# Altium schematic sheet Payload_Switch.SchDoc
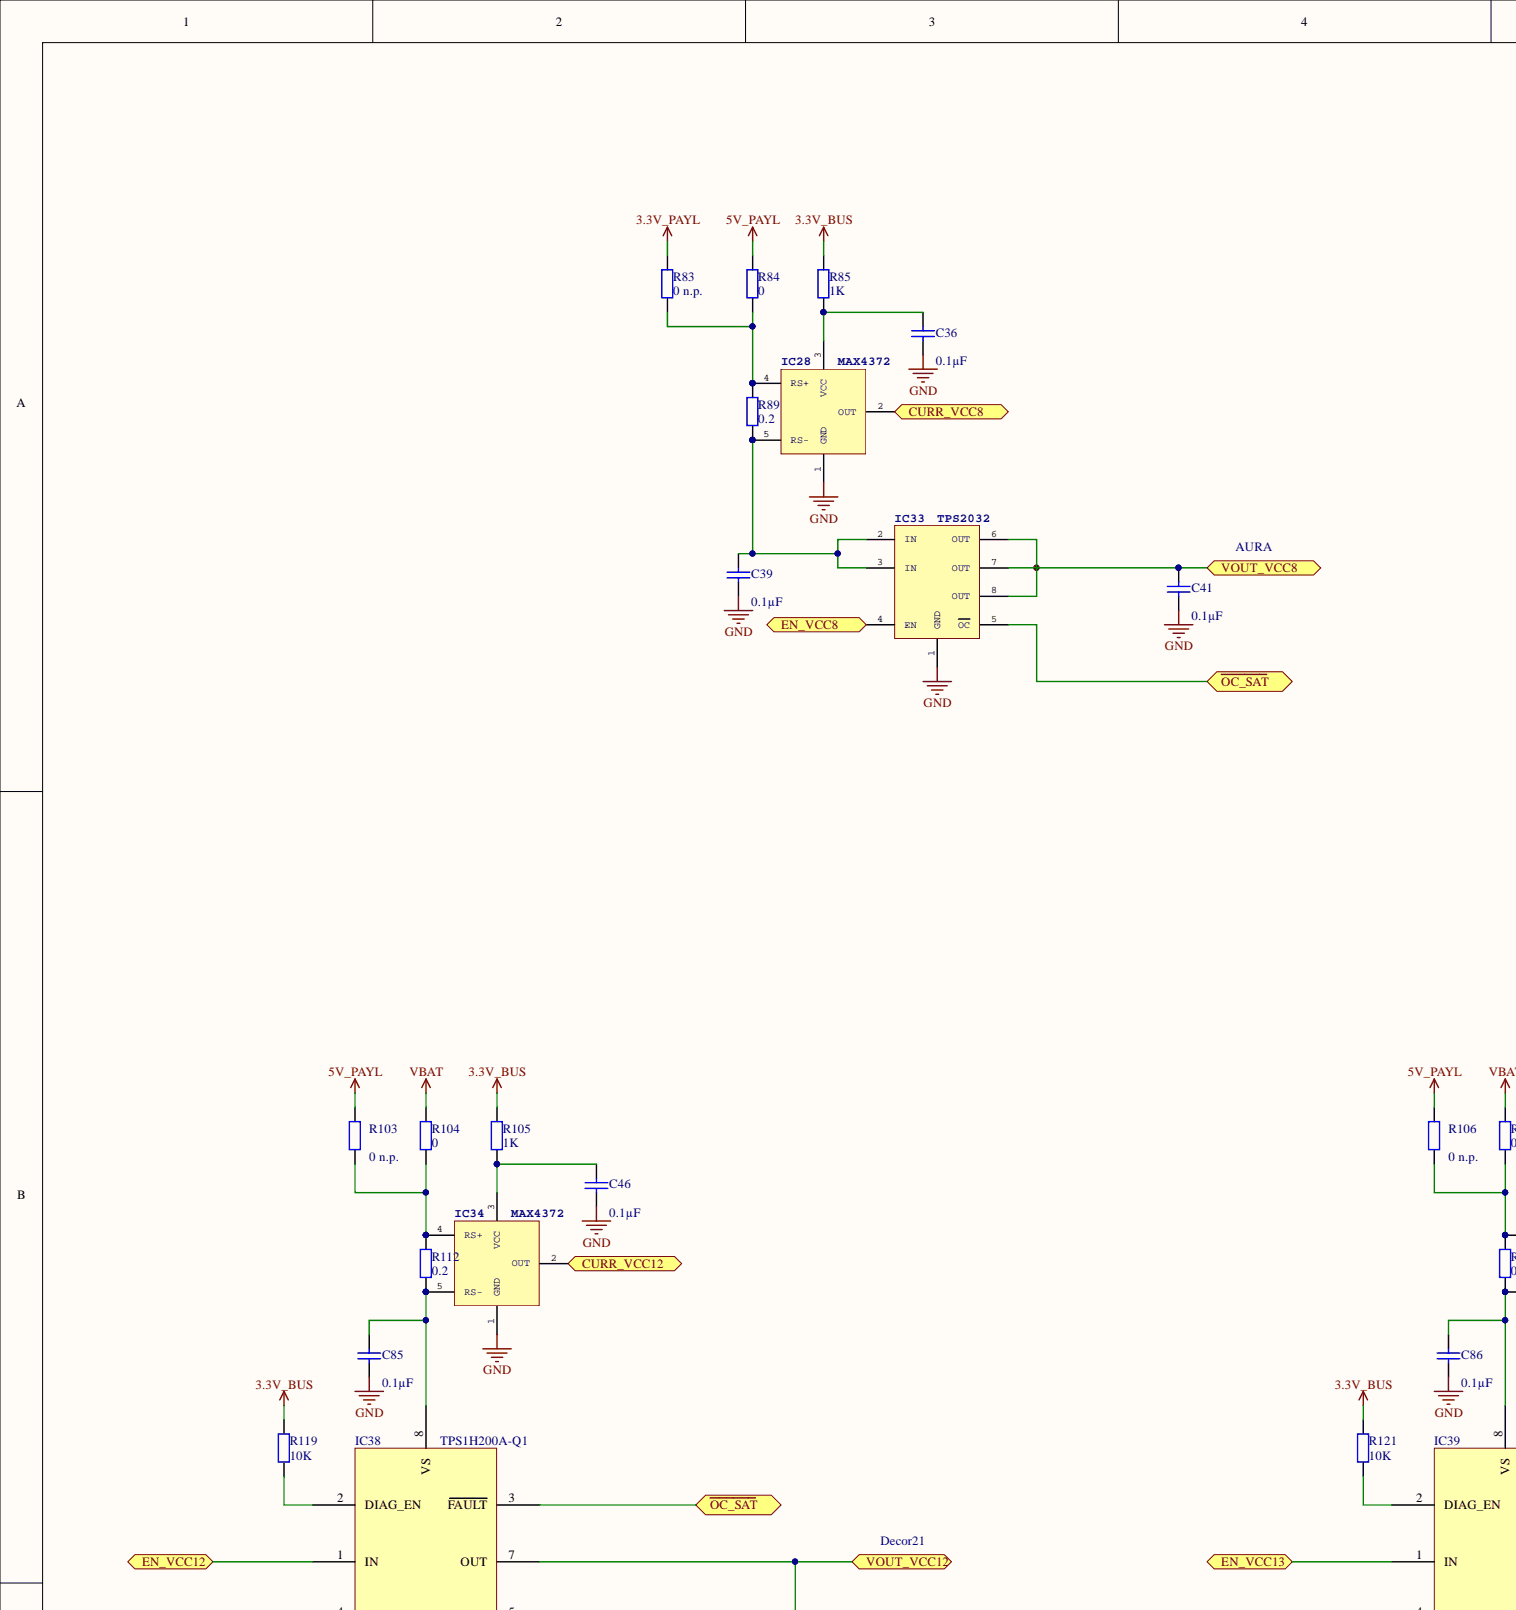
[diagram p0: Payload_Switch.SchDoc, top left]
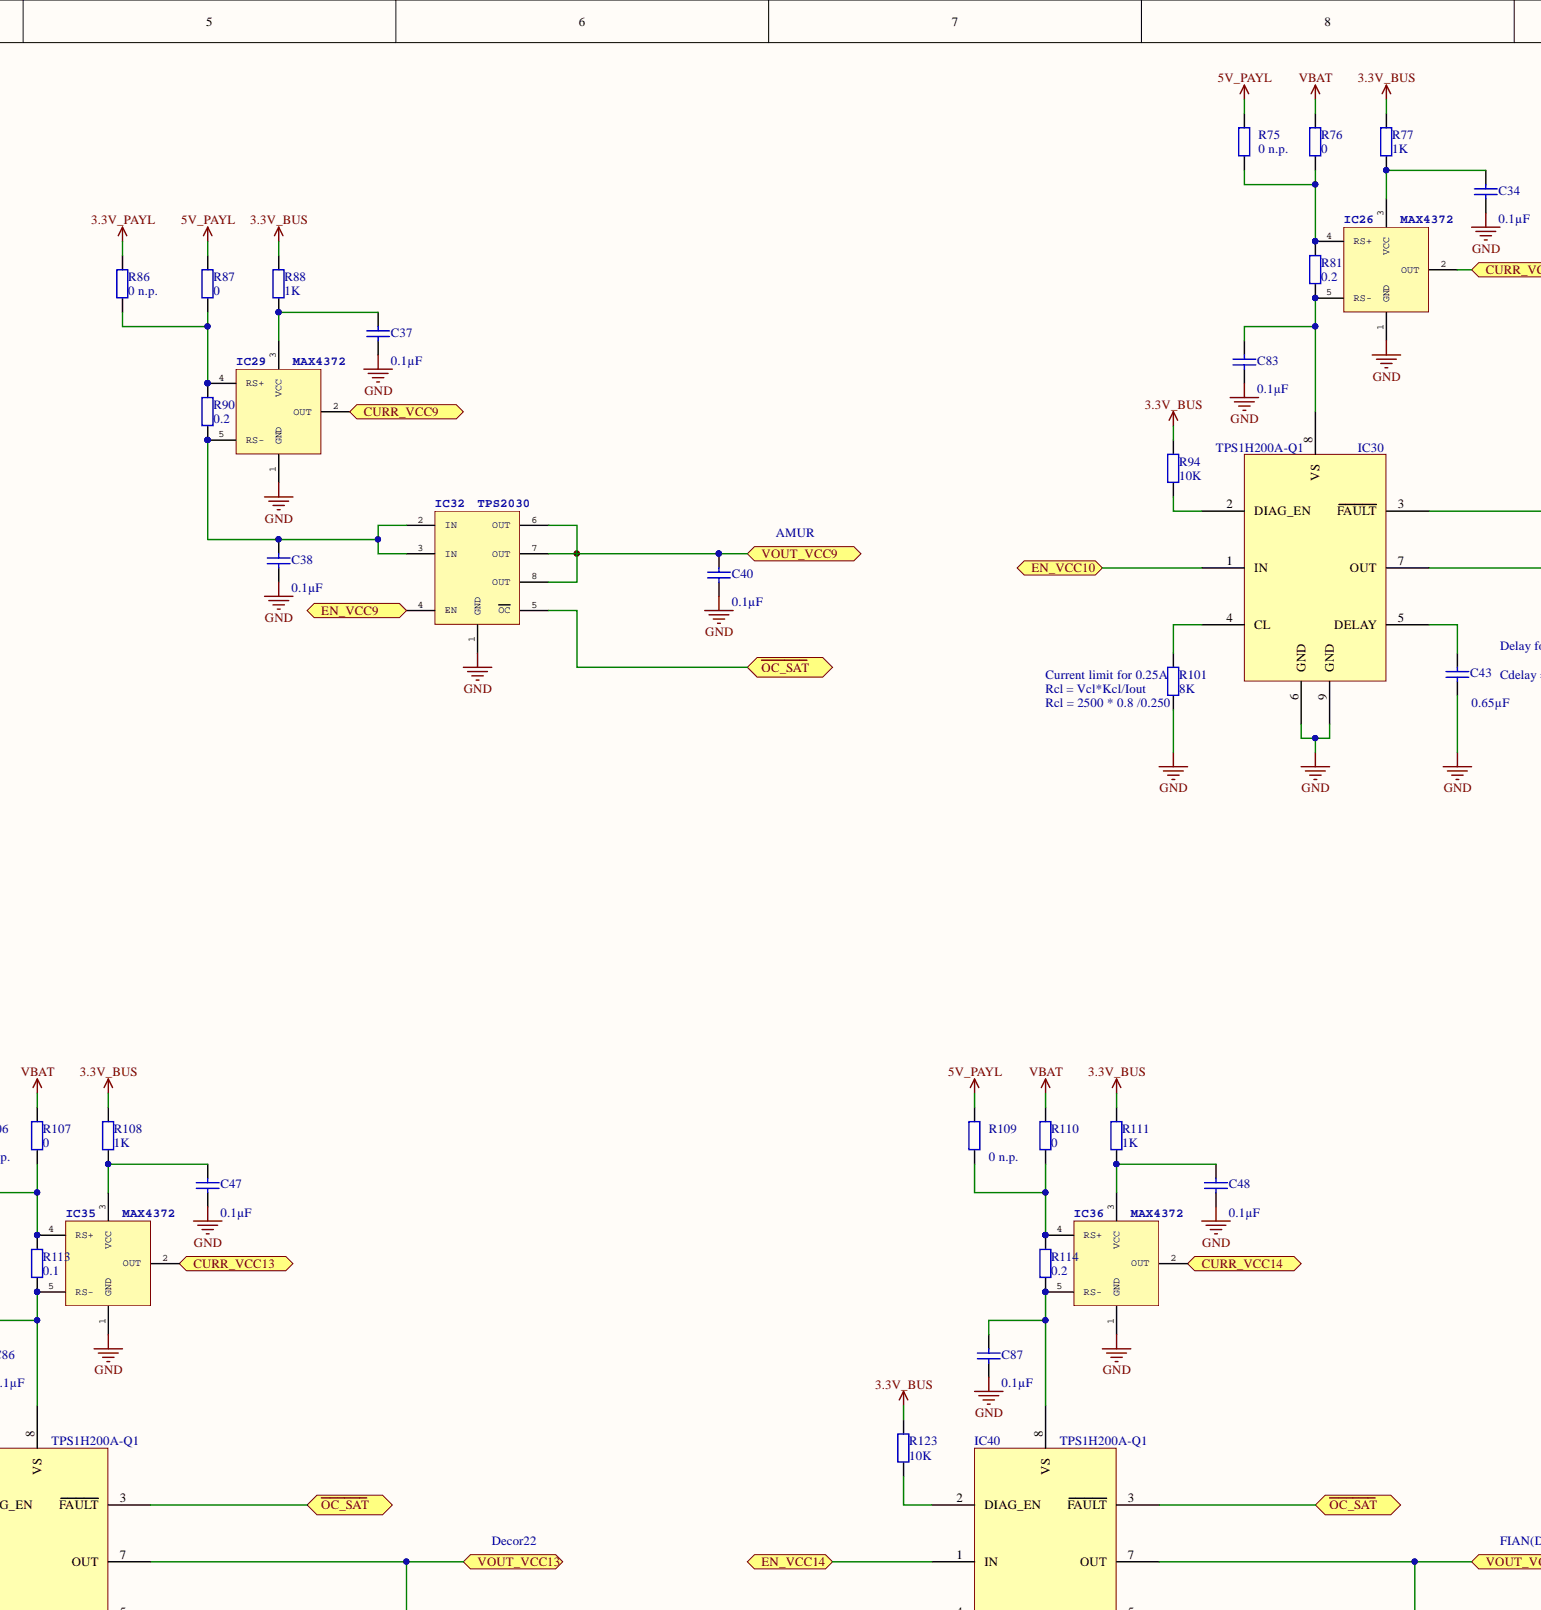
[diagram p1: Payload_Switch.SchDoc, top centre]
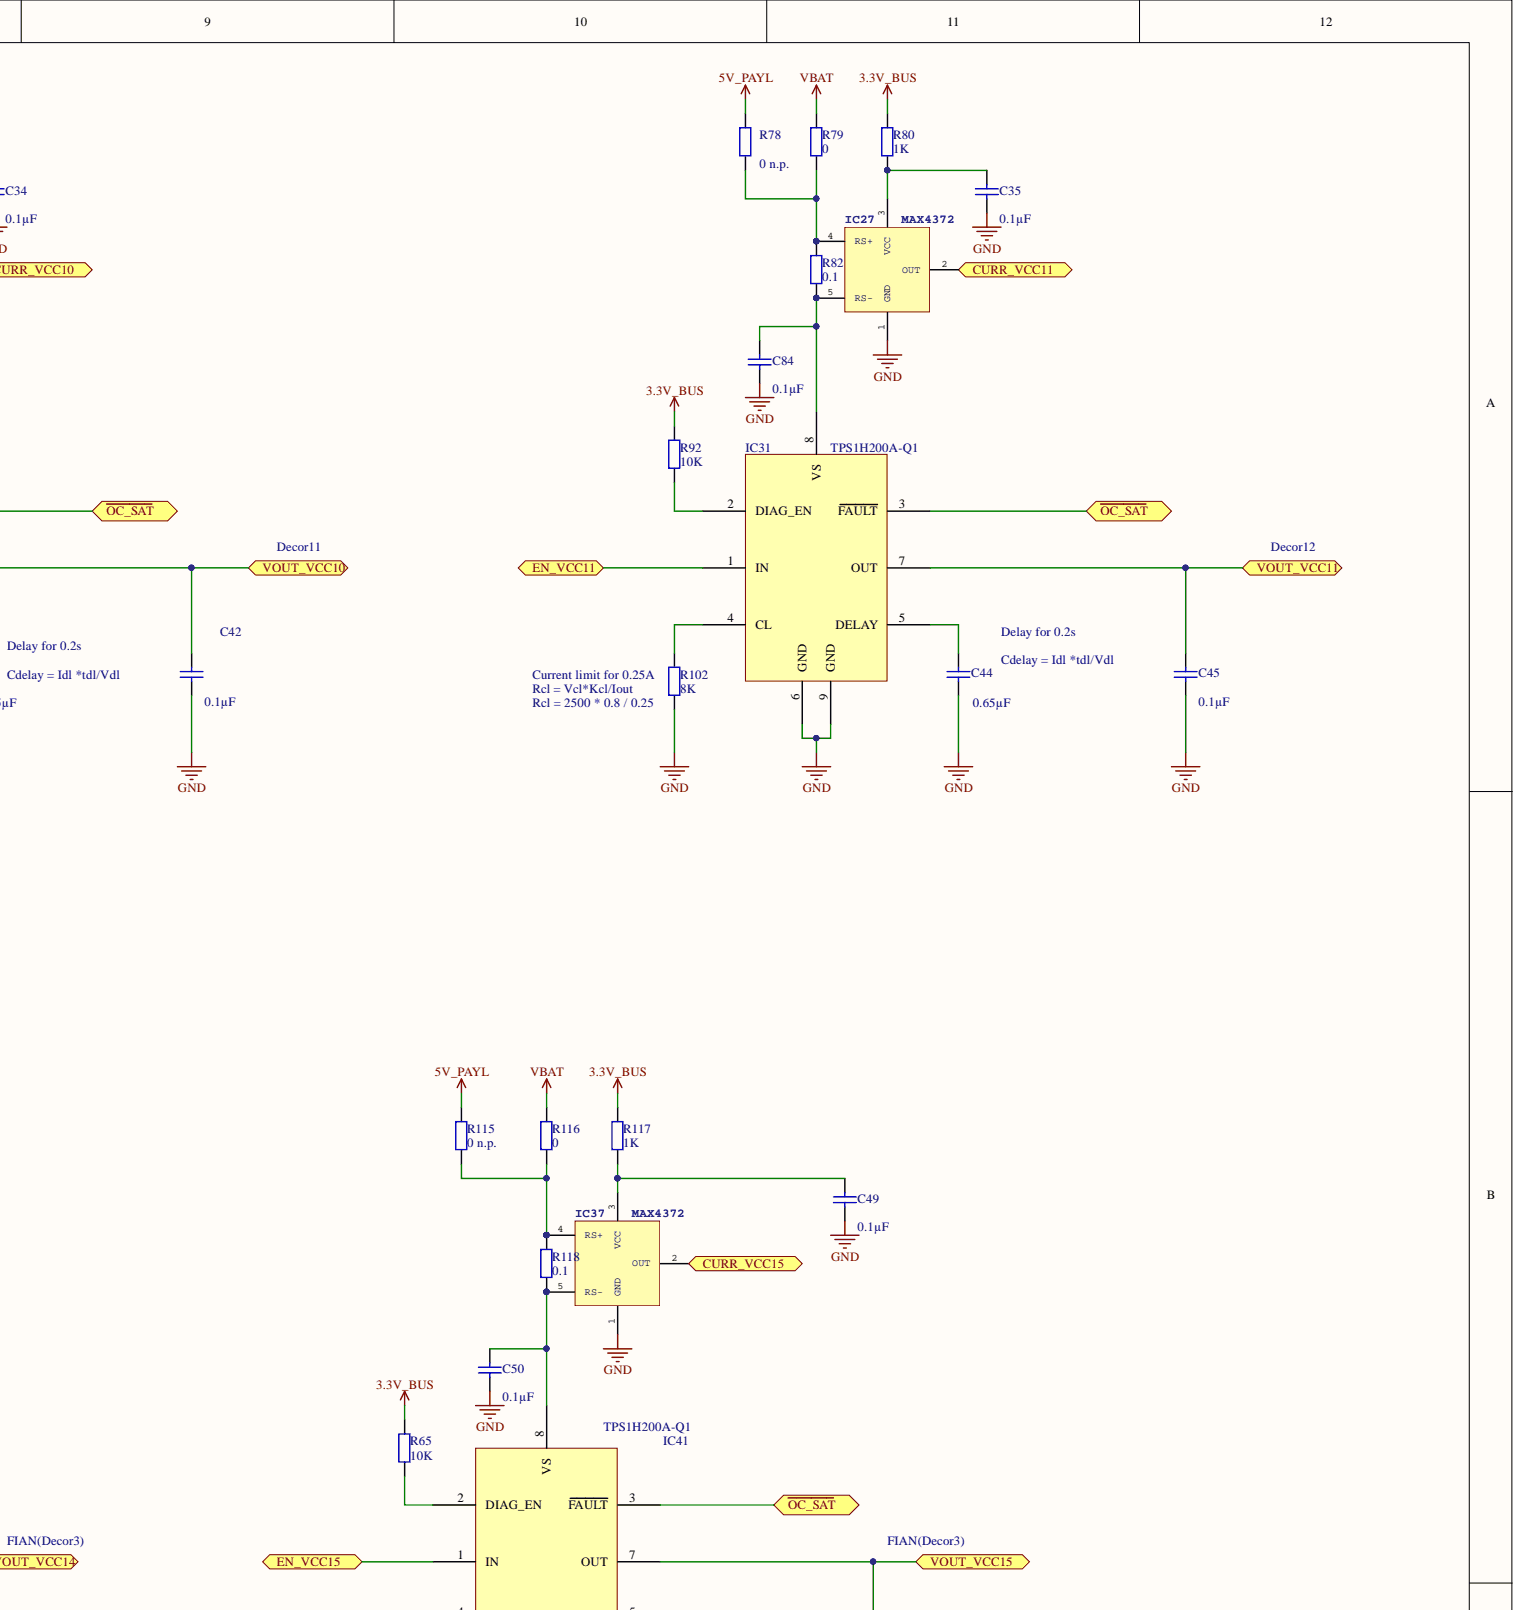
[diagram p2: Payload_Switch.SchDoc, top right]
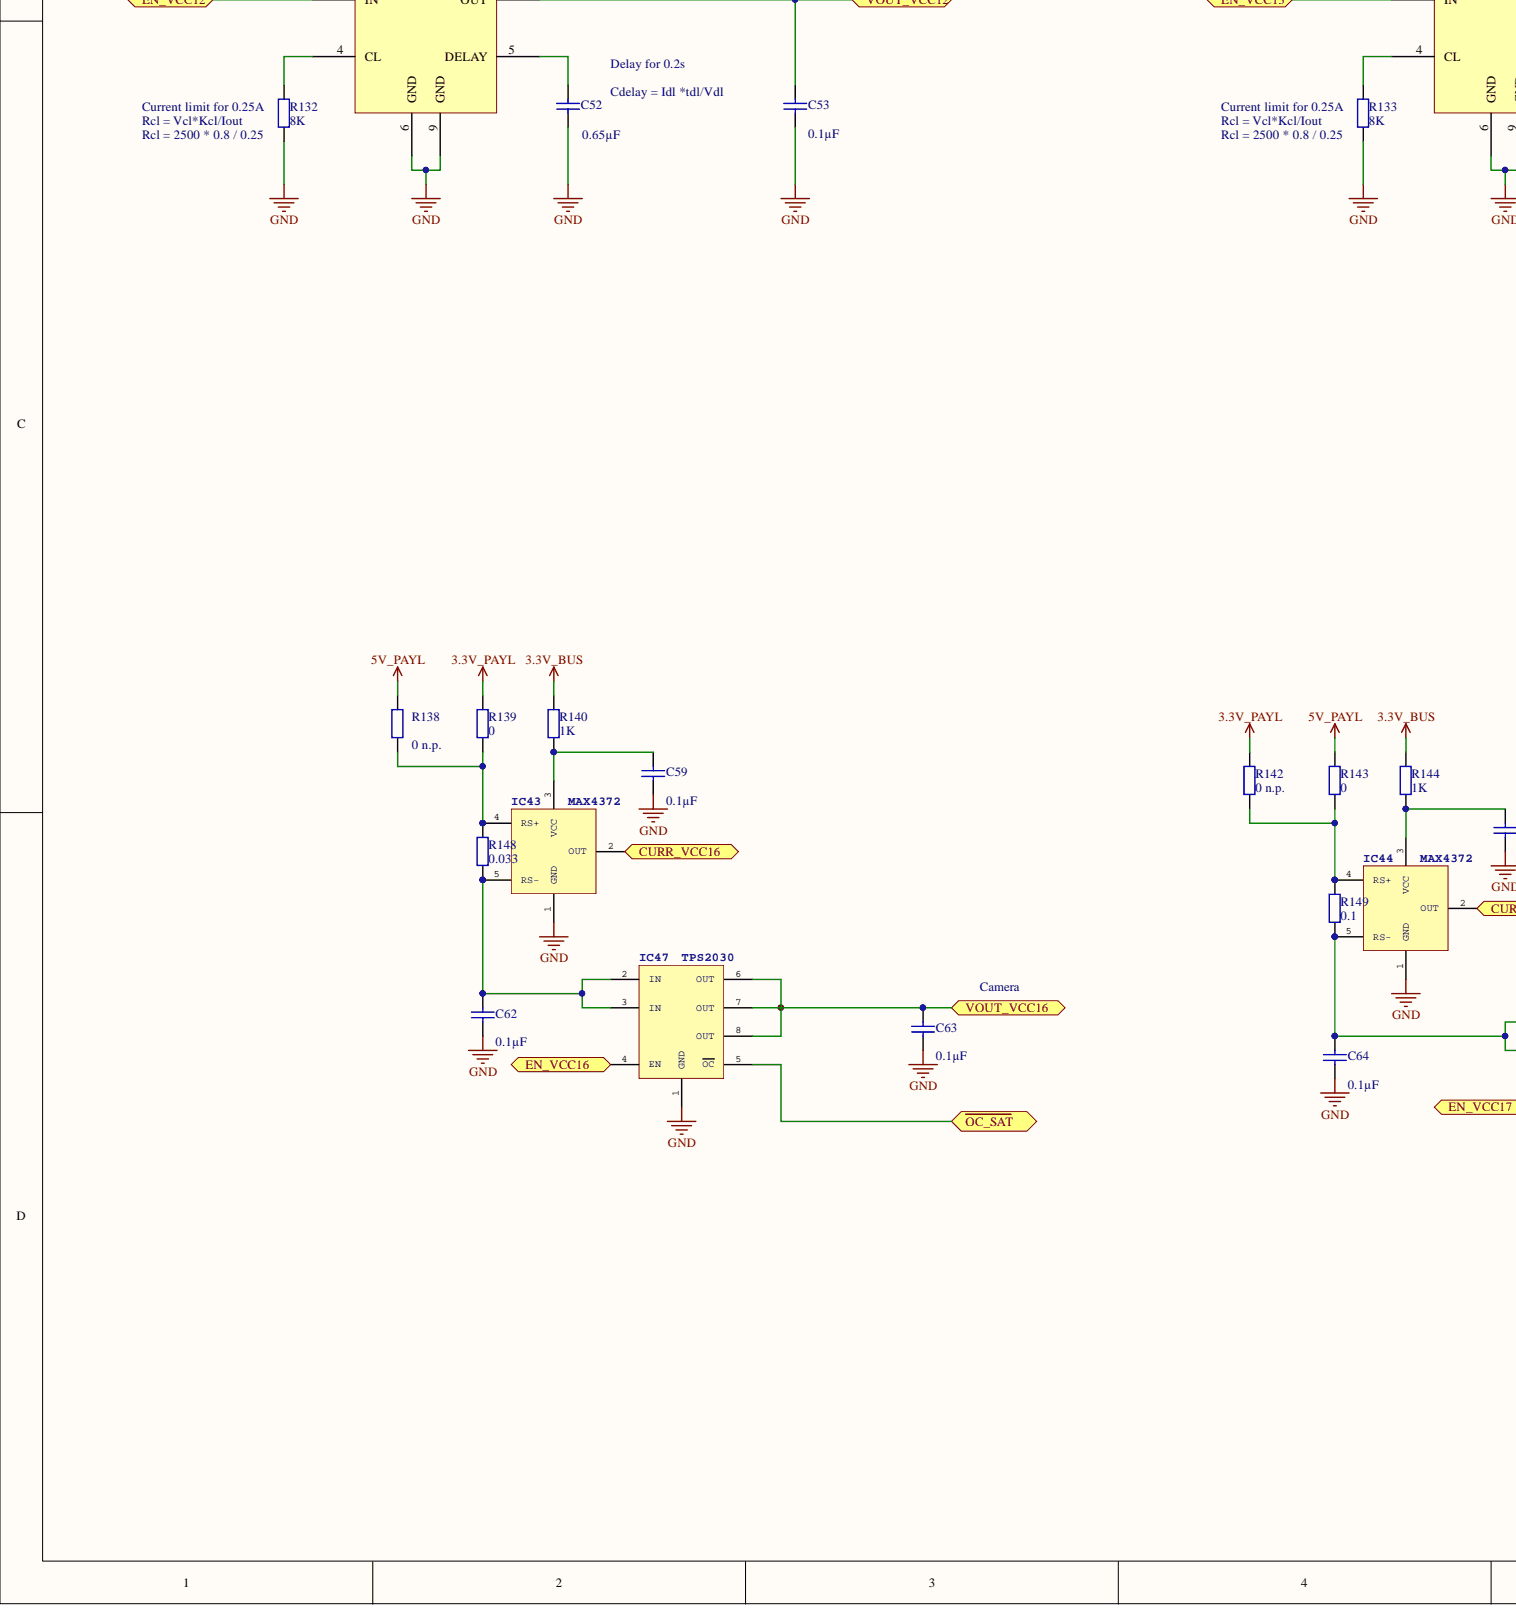
[diagram p3: Payload_Switch.SchDoc, bottom left]
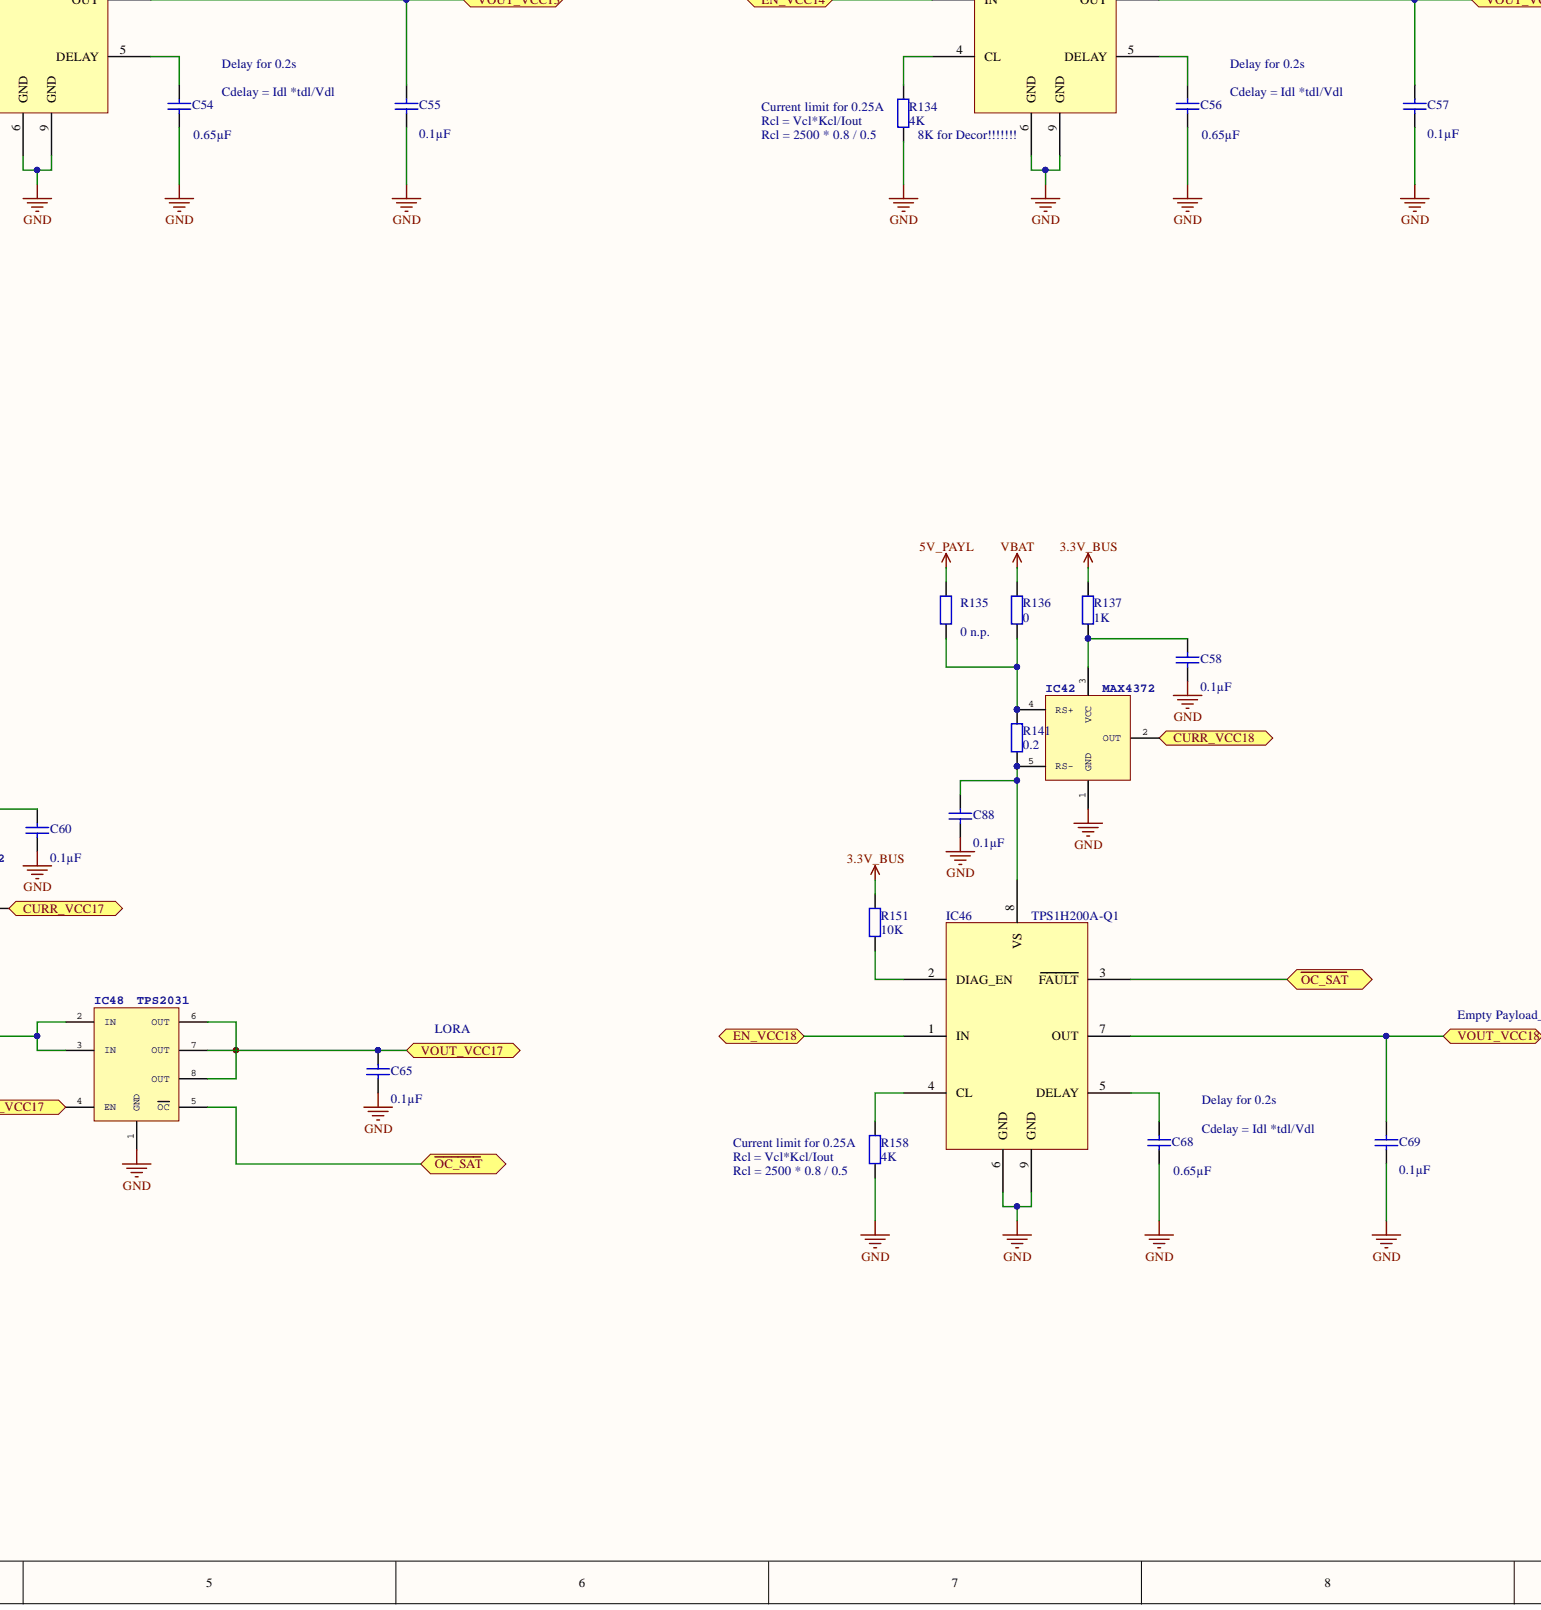
[diagram p4: Payload_Switch.SchDoc, bottom centre]
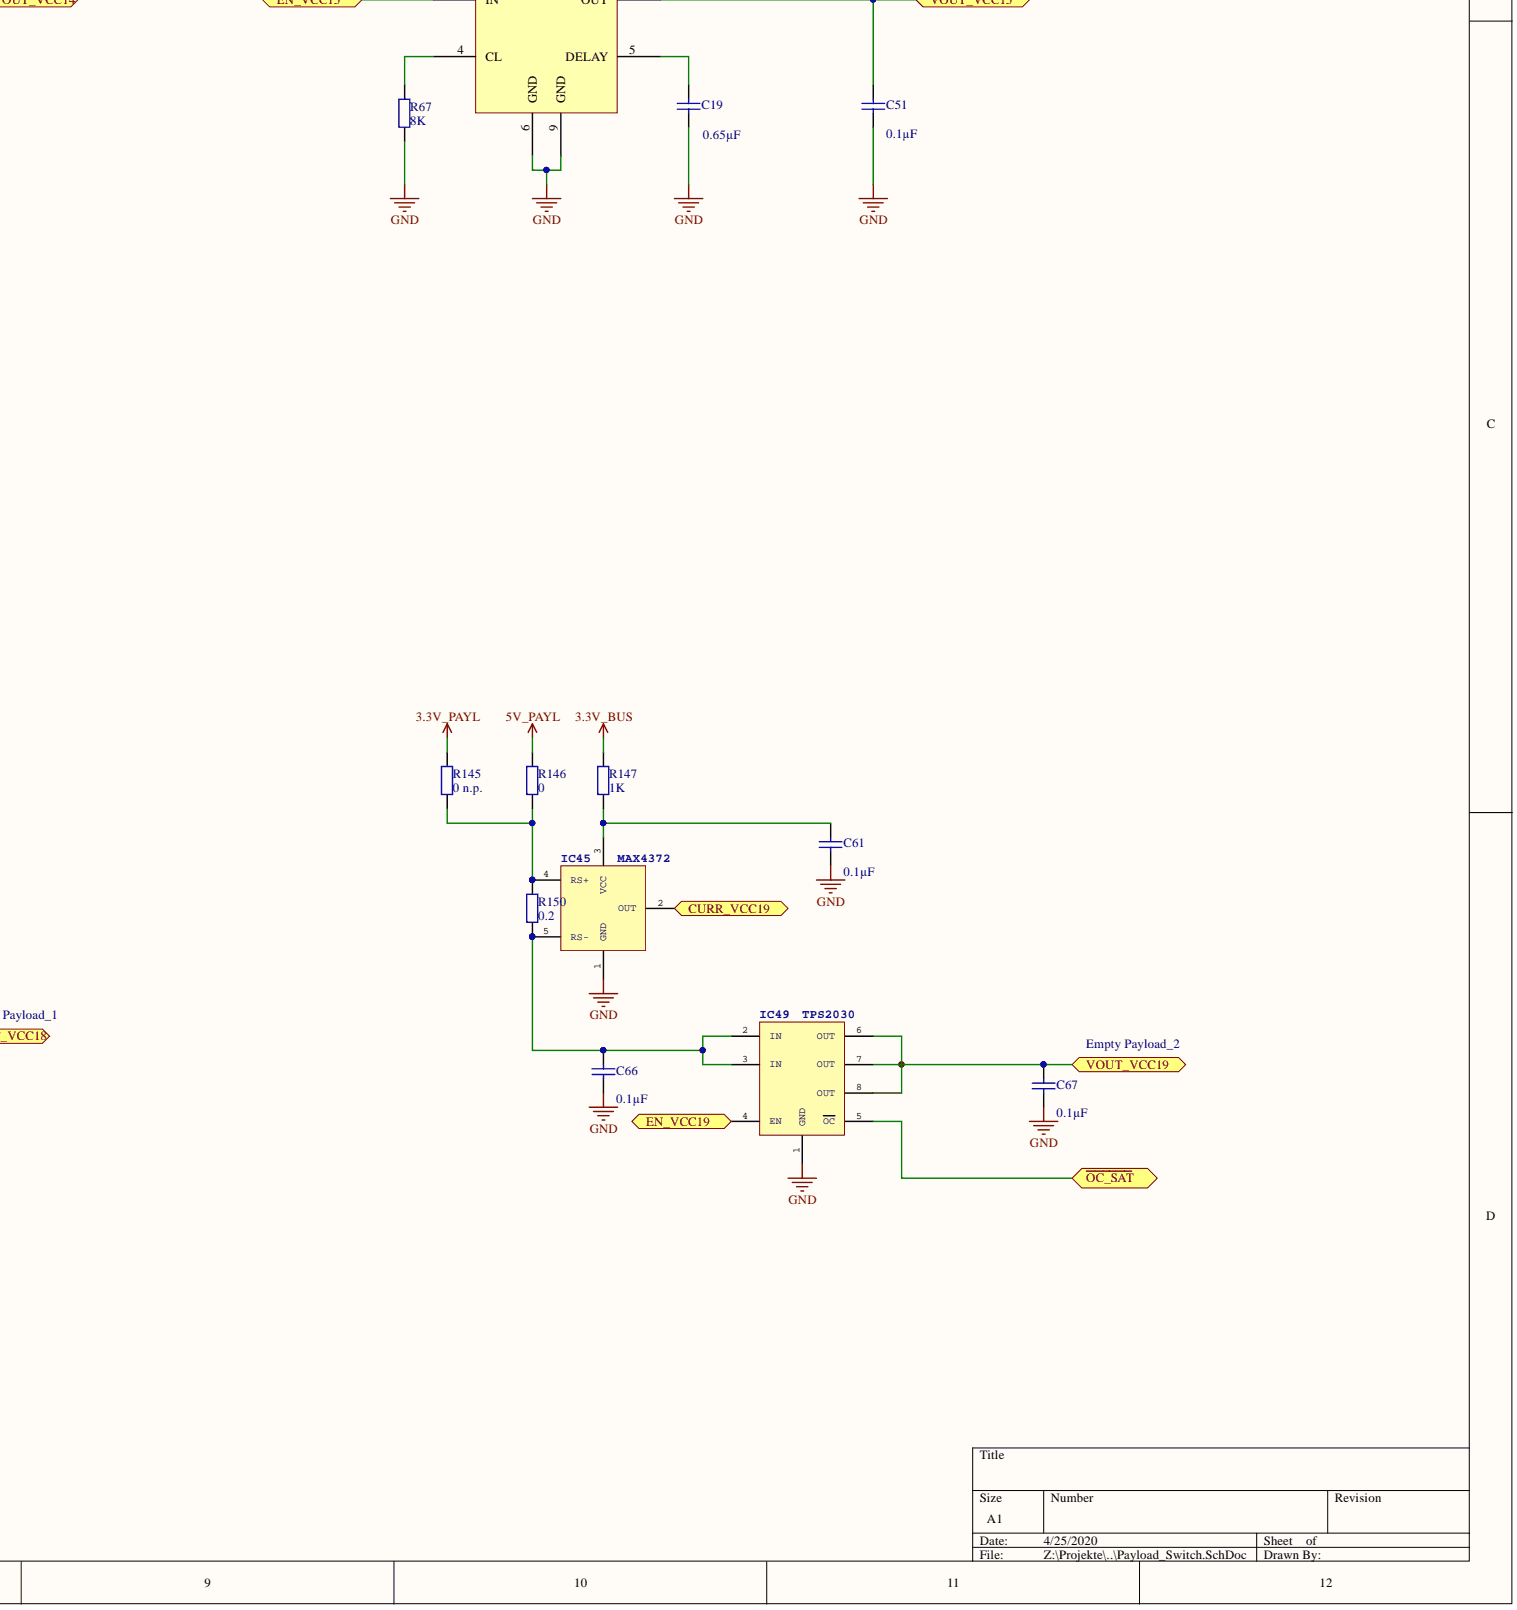
[diagram p5: Payload_Switch.SchDoc, bottom right]

## Parts
C19  0.65µF  [1608[0603]]
C34  0.1µF  [1608[0603]]
C35  0.1µF  [1608[0603]]
C36  0.1µF  [1608[0603]]
C37  0.1µF  [1608[0603]]
C38  0.1µF  [1608[0603]]
C39  0.1µF  [1608[0603]]
C40  0.1µF  [1608[0603]]
C41  0.1µF  [1608[0603]]
C42  0.1µF  [1608[0603]]
C43  0.65µF  [1608[0603]]
C44  0.65µF  [1608[0603]]
C45  0.1µF  [1608[0603]]
C46  0.1µF  [1608[0603]]
C47  0.1µF  [1608[0603]]
C48  0.1µF  [1608[0603]]
C49  0.1µF  [1608[0603]]
C50  0.1µF  [1608[0603]]
C51  0.1µF  [1608[0603]]
C52  0.65µF  [1608[0603]]
C53  0.1µF  [1608[0603]]
C54  0.65µF  [1608[0603]]
C55  0.1µF  [1608[0603]]
C56  0.65µF  [1608[0603]]
C57  0.1µF  [1608[0603]]
C58  0.1µF  [1608[0603]]
C59  0.1µF  [1608[0603]]
C60  0.1µF  [1608[0603]]
C61  0.1µF  [1608[0603]]
C62  0.1µF  [1608[0603]]
C63  0.1µF  [1608[0603]]
C64  0.1µF  [1608[0603]]
C65  0.1µF  [1608[0603]]
C66  0.1µF  [1608[0603]]
C67  0.1µF  [1608[0603]]
C68  0.65µF  [1608[0603]]
C69  0.1µF  [1608[0603]]
C83  0.1µF  [1608[0603]]
C84  0.1µF  [1608[0603]]
C85  0.1µF  [1608[0603]]
C86  0.1µF  [1608[0603]]
C87  0.1µF  [1608[0603]]
C88  0.1µF  [1608[0603]]
IC26  MAX4372  [SOT23-5L]
IC27  MAX4372  [SOT23-5L]
IC28  MAX4372  [SOT23-5L]
IC29  MAX4372  [SOT23-5L]
IC30  TPS1H200A-Q1  [SON65P300X100_HS-9N_SW]
IC31  TPS1H200A-Q1  [SON65P300X100_HS-9N_SW]
IC32  TPS2030  [SO08]
IC33  TPS2032  [SO08]
IC34  MAX4372  [SOT23-5L]
IC35  MAX4372  [SOT23-5L]
IC36  MAX4372  [SOT23-5L]
IC37  MAX4372  [SOT23-5L]
IC38  TPS1H200A-Q1  [SON65P300X100_HS-9N_SW]
IC39  TPS1H200A-Q1  [SON65P300X100_HS-9N_SW]
IC40  TPS1H200A-Q1  [SON65P300X100_HS-9N_SW]
IC41  TPS1H200A-Q1  [SON65P300X100_HS-9N_SW]
IC42  MAX4372  [SOT23-5L]
IC43  MAX4372  [SOT23-5L]
IC44  MAX4372  [SOT23-5L]
IC45  MAX4372  [SOT23-5L]
IC46  TPS1H200A-Q1  [SON65P300X100_HS-9N_SW]
IC47  TPS2030  [SO08]
IC48  TPS2031  [SO08]
IC49  TPS2030  [SO08]
R65  10K  [1608[0603]]
R67  8K  [1608[0603]]
R75  0 n.p.  [6-0805_N]
R76  0  [6-0805_N]
R77  1K  [1608[0603]]
R78  0 n.p.  [6-0805_N]
R79  0  [6-0805_N]
R80  1K  [1608[0603]]
R81  0.2  [1206]
R82  0.1  [1206]
R83  0 n.p.  [6-0805_N]
R84  0  [6-0805_N]
R85  1K  [1608[0603]]
R86  0 n.p.  [6-0805_N]
R87  0  [6-0805_N]
R88  1K  [1608[0603]]
R89  0.2  [1206]
R90  0.2  [1206]
R92  10K  [1608[0603]]
R94  10K  [1608[0603]]
R101  8K  [1608[0603]]
R102  8K  [1608[0603]]
R103  0 n.p.  [6-0805_N]
R104  0  [6-0805_N]
R105  1K  [1608[0603]]
R106  0 n.p.  [6-0805_N]
R107  0  [6-0805_N]
R108  1K  [1608[0603]]
R109  0 n.p.  [6-0805_N]
R110  0  [6-0805_N]
R111  1K  [1608[0603]]
R112  0.2  [1206]
R113  0.1  [1206]
R114  0.2  [1206]
R115  0 n.p.  [6-0805_N]
R116  0  [6-0805_N]
R117  1K  [1608[0603]]
R118  0.1  [1206]
R119  10K  [1608[0603]]
R121  10K  [1608[0603]]
R123  10K  [1608[0603]]
R132  8K  [1608[0603]]
R133  8K  [1608[0603]]
R134  4K  [1608[0603]]
R135  0 n.p.  [6-0805_N]
R136  0  [6-0805_N]
R137  1K  [1608[0603]]
R138  0 n.p.  [6-0805_N]
R139  0  [6-0805_N]
R140  1K  [1608[0603]]
R141  0.2  [1206]
R142  0 n.p.  [6-0805_N]
R143  0  [6-0805_N]
R144  1K  [1608[0603]]
R145  0 n.p.  [6-0805_N]
R146  0  [6-0805_N]
R147  1K  [1608[0603]]
R148  0.033  [1206]
R149  0.1  [1206]
R150  0.2  [1206]
R151  10K  [1608[0603]]
R158  4K  [1608[0603]]

## Ports
CURR_VCC8, CURR_VCC9, CURR_VCC10, CURR_VCC11, CURR_VCC12, CURR_VCC13, CURR_VCC14, CURR_VCC15, CURR_VCC16, CURR_VCC17, CURR_VCC18, CURR_VCC19, EN_VCC8, EN_VCC9, EN_VCC10, EN_VCC11, EN_VCC12, EN_VCC13, EN_VCC14, EN_VCC15, EN_VCC16, EN_VCC17, EN_VCC18, EN_VCC19, VOUT_VCC8, VOUT_VCC9, VOUT_VCC10, VOUT_VCC11, VOUT_VCC12, VOUT_VCC13, VOUT_VCC14, VOUT_VCC15, VOUT_VCC16, VOUT_VCC17, VOUT_VCC18, VOUT_VCC19, ~{OC_SAT}

## Nets
3.3V_BUS: R65.1 R77.2 R80.2 R85.2 R88.2 R92.1 R94.1 R105.2 R108.2 R111.2 R117.2 R119.1 R121.1 R123.1 R137.2 R140.2 R144.2 R147.2 R151.1
3.3V_PAYL: R83.2 R86.2 R139.2 R142.2 R145.2
5V_PAYL: R75.2 R78.2 R84.2 R87.2 R103.2 R106.2 R109.2 R115.2 R135.2 R138.2 R143.2 R146.2
GND: C19.1 C34.1 C35.1 C36.1 C37.1 C38.1 C39.1 C40.1 C41.1 C42.1 C43.1 C44.1 C45.1 C46.1 C47.1 C48.1 C49.1 C50.1 C51.1 C52.1 C53.1 C54.1 C55.1 C56.1 C57.1 C58.1 C59.1 C60.1 C61.1 C62.1 C63.1 C64.1 C65.1 C66.1 C67.1 C68.1 C69.1 C83.1 C84.1 C85.1 C86.1 C87.1 C88.1 IC26.1(GND) IC27.1(GND) IC28.1(GND) IC29.1(GND) IC30.6(GND) IC30.9(GND) IC31.6(GND) IC31.9(GND) IC32.1(GND) IC33.1(GND) IC34.1(GND) IC35.1(GND) IC36.1(GND) IC37.1(GND) IC38.6(GND) IC38.9(GND) IC39.6(GND) IC39.9(GND) IC40.6(GND) IC40.9(GND) IC41.6(GND) IC41.9(GND) IC42.1(GND) IC43.1(GND) IC44.1(GND) IC45.1(GND) IC46.6(GND) IC46.9(GND) IC47.1(GND) IC48.1(GND) IC49.1(GND) R67.1 R101.1 R102.1 R132.1 R133.1 R134.1 R158.1
VBAT: R76.2 R79.2 R104.2 R107.2 R110.2 R116.2 R136.2
CURR_VCC8: IC28.2(OUT) ; port CURR_VCC8
CURR_VCC9: IC29.2(OUT) ; port CURR_VCC9
CURR_VCC10: IC26.2(OUT) ; port CURR_VCC10
CURR_VCC11: IC27.2(OUT) ; port CURR_VCC11
CURR_VCC12: IC34.2(OUT) ; port CURR_VCC12
CURR_VCC13: IC35.2(OUT) ; port CURR_VCC13
CURR_VCC14: IC36.2(OUT) ; port CURR_VCC14
CURR_VCC15: IC37.2(OUT) ; port CURR_VCC15
CURR_VCC16: IC43.2(OUT) ; port CURR_VCC16
CURR_VCC17: IC44.2(OUT) ; port CURR_VCC17
CURR_VCC18: IC42.2(OUT) ; port CURR_VCC18
CURR_VCC19: IC45.2(OUT) ; port CURR_VCC19
EN_VCC8: IC33.4(EN) ; port EN_VCC8
EN_VCC9: IC32.4(EN) ; port EN_VCC9
EN_VCC10: IC30.1(IN) ; port EN_VCC10
EN_VCC11: IC31.1(IN) ; port EN_VCC11
EN_VCC12: IC38.1(IN) ; port EN_VCC12
EN_VCC13: IC39.1(IN) ; port EN_VCC13
EN_VCC14: IC40.1(IN) ; port EN_VCC14
EN_VCC15: IC41.1(IN) ; port EN_VCC15
EN_VCC16: IC47.4(EN) ; port EN_VCC16
EN_VCC17: IC48.4(EN) ; port EN_VCC17
EN_VCC18: IC46.1(IN) ; port EN_VCC18
EN_VCC19: IC49.4(EN) ; port EN_VCC19
~{OC_SAT}: IC30.3(FAULT) IC31.3(FAULT) IC32.5(OC) IC33.5(OC) IC38.3(FAULT) IC39.3(FAULT) IC40.3(FAULT) IC41.3(FAULT) IC46.3(FAULT) IC47.5(OC) IC48.5(OC) IC49.5(OC) ; port ~{OC_SAT}
VOUT_VCC8: C41.2 IC33.6(OUT) IC33.7(OUT) IC33.8(OUT) ; port VOUT_VCC8
VOUT_VCC9: C40.2 IC32.6(OUT) IC32.7(OUT) IC32.8(OUT) ; port VOUT_VCC9
VOUT_VCC10: C42.2 IC30.7(OUT) ; port VOUT_VCC10
VOUT_VCC11: C45.2 IC31.7(OUT) ; port VOUT_VCC11
VOUT_VCC12: C53.2 IC38.7(OUT) ; port VOUT_VCC12
VOUT_VCC13: C55.2 IC39.7(OUT) ; port VOUT_VCC13
VOUT_VCC14: C57.2 IC40.7(OUT) ; port VOUT_VCC14
VOUT_VCC15: C51.2 IC41.7(OUT) ; port VOUT_VCC15
VOUT_VCC16: C63.2 IC47.6(OUT) IC47.7(OUT) IC47.8(OUT) ; port VOUT_VCC16
VOUT_VCC17: C65.2 IC48.6(OUT) IC48.7(OUT) IC48.8(OUT) ; port VOUT_VCC17
VOUT_VCC18: C69.2 IC46.7(OUT) ; port VOUT_VCC18
VOUT_VCC19: C67.2 IC49.6(OUT) IC49.7(OUT) IC49.8(OUT) ; port VOUT_VCC19
NetC19_2: C19.2 IC41.5(DELAY)
NetC34_2: C34.2 IC26.3(VCC) R77.1
NetC35_2: C35.2 IC27.3(VCC) R80.1
NetC36_2: C36.2 IC28.3(VCC) R85.1
NetC37_2: C37.2 IC29.3(VCC) R88.1
NetC38_2: C38.2 IC29.5(RS-) IC32.2(IN) IC32.3(IN) R90.1
NetC39_2: C39.2 IC28.5(RS-) IC33.2(IN) IC33.3(IN) R89.1
NetC43_2: C43.2 IC30.5(DELAY)
NetC44_2: C44.2 IC31.5(DELAY)
NetC46_2: C46.2 IC34.3(VCC) R105.1
NetC47_2: C47.2 IC35.3(VCC) R108.1
NetC48_2: C48.2 IC36.3(VCC) R111.1
NetC49_2: C49.2 IC37.3(VCC) R117.1
NetC50_2: C50.2 IC37.5(RS-) IC41.8(VS) R118.1
NetC52_2: C52.2 IC38.5(DELAY)
NetC54_2: C54.2 IC39.5(DELAY)
NetC56_2: C56.2 IC40.5(DELAY)
NetC58_2: C58.2 IC42.3(VCC) R137.1
NetC59_2: C59.2 IC43.3(VCC) R140.1
NetC60_2: C60.2 IC44.3(VCC) R144.1
NetC61_2: C61.2 IC45.3(VCC) R147.1
NetC62_2: C62.2 IC43.5(RS-) IC47.2(IN) IC47.3(IN) R148.1
NetC64_2: C64.2 IC44.5(RS-) IC48.2(IN) IC48.3(IN) R149.1
NetC66_2: C66.2 IC45.5(RS-) IC49.2(IN) IC49.3(IN) R150.1
NetC68_2: C68.2 IC46.5(DELAY)
NetC83_2: C83.2 IC26.5(RS-) IC30.8(VS) R81.1
NetC84_2: C84.2 IC27.5(RS-) IC31.8(VS) R82.1
NetC85_2: C85.2 IC34.5(RS-) IC38.8(VS) R112.1
NetC86_2: C86.2 IC35.5(RS-) IC39.8(VS) R113.1
NetC87_2: C87.2 IC36.5(RS-) IC40.8(VS) R114.1
NetC88_2: C88.2 IC42.5(RS-) IC46.8(VS) R141.1
NetIC26_4: IC26.4(RS+) R75.1 R76.1 R81.2
NetIC27_4: IC27.4(RS+) R78.1 R79.1 R82.2
NetIC28_4: IC28.4(RS+) R83.1 R84.1 R89.2
NetIC29_4: IC29.4(RS+) R86.1 R87.1 R90.2
NetIC30_2: IC30.2(DIAG_EN) R94.2
NetIC30_4: IC30.4(CL) R101.2
NetIC31_2: IC31.2(DIAG_EN) R92.2
NetIC31_4: IC31.4(CL) R102.2
NetIC34_4: IC34.4(RS+) R103.1 R104.1 R112.2
NetIC35_4: IC35.4(RS+) R106.1 R107.1 R113.2
NetIC36_4: IC36.4(RS+) R109.1 R110.1 R114.2
NetIC37_4: IC37.4(RS+) R115.1 R116.1 R118.2
NetIC38_2: IC38.2(DIAG_EN) R119.2
NetIC38_4: IC38.4(CL) R132.2
NetIC39_2: IC39.2(DIAG_EN) R121.2
NetIC39_4: IC39.4(CL) R133.2
NetIC40_2: IC40.2(DIAG_EN) R123.2
NetIC40_4: IC40.4(CL) R134.2
NetIC41_2: IC41.2(DIAG_EN) R65.2
NetIC41_4: IC41.4(CL) R67.2
NetIC42_4: IC42.4(RS+) R135.1 R136.1 R141.2
NetIC43_4: IC43.4(RS+) R138.1 R139.1 R148.2
NetIC44_4: IC44.4(RS+) R142.1 R143.1 R149.2
NetIC45_4: IC45.4(RS+) R145.1 R146.1 R150.2
NetIC46_2: IC46.2(DIAG_EN) R151.2
NetIC46_4: IC46.4(CL) R158.2

## Library data of the parts
C19 C34-C69 C83-C88 = Cap: Capacitor; Component Kind: Standard; LatestRevisionDate: 17-Jul-2002; LatestRevisionNote: Re-released for DXP Platform.; Library Name: Miscellaneous Devices.IntLib; PackageDescription: Radial Cap, Thru-Hole; 2 Leads; 0.3 in Pin Spacing; PackageReference: RAD-0.3; Published: 8-Jun-2000; Signal Integrity: Cap; Simulation: CAP; Publisher: Altium Limited
  C19 C43 C44 C52 C54 C56 C68: Value: 0.65µF
  C34-C42 C45-C51 C53 C55 C57-C67 C69 C83-C88: Value: 0.1µF
IC32 IC33 IC47-IC49 = TPS203*D: Component Kind: Standard; Library Name: EPS.SCHLIB
R65 R67 R75-R90 R92 R94 R101-R119 R121 R123 R132-R151 R158 = Res2: Resistor; Component Kind: Standard; LatestRevisionDate: 17-Jul-2002; LatestRevisionNote: Re-released for DXP Platform.; Library Name: Miscellaneous Devices.IntLib; PackageDescription: Axial Device, Thru-Hole; 2 Leads; 0.4 in Pin Spacing; PackageReference: AXIAL-0.4; Published: 8-Jun-2000; Signal Integrity: Res; Simulation: RESISTOR; Publisher: Altium Limited
  R65 R92 R94 R119 R121 R123 R151: Value: 10K
  R67 R101 R102 R132 R133: Value: 8K
  R75 R78 R83 R86 R103 R106 R109 R115 R135 R138 R142 R145: Value: 0 n.p.
  R76 R79 R84 R87 R104 R107 R110 R116 R136 R139 R143 R146: Value: 0
  R77 R80 R85 R88 R105 R108 R111 R117 R137 R140 R144 R147: Value: 1K
  R81 R89 R90 R112 R114 R141 R150: Value: 0.2
  R82 R113 R118 R149: Value: 0.1
  R134 R158: Value: 4K
  R148: Value: 0.033
IC26-IC29 IC34-IC37 IC42-IC45 = MAX4372: Component Kind: Standard; Library Name: EPS.SCHLIB
IC30 IC31 IC38-IC41 IC46 = TPS1H200A-Q1: Component Kind: Standard; Library Name: Battery_pack_pavel.SchLib
